# Altium schematic sheet Keyboard_Mouse_LED_Monitor.SchDoc
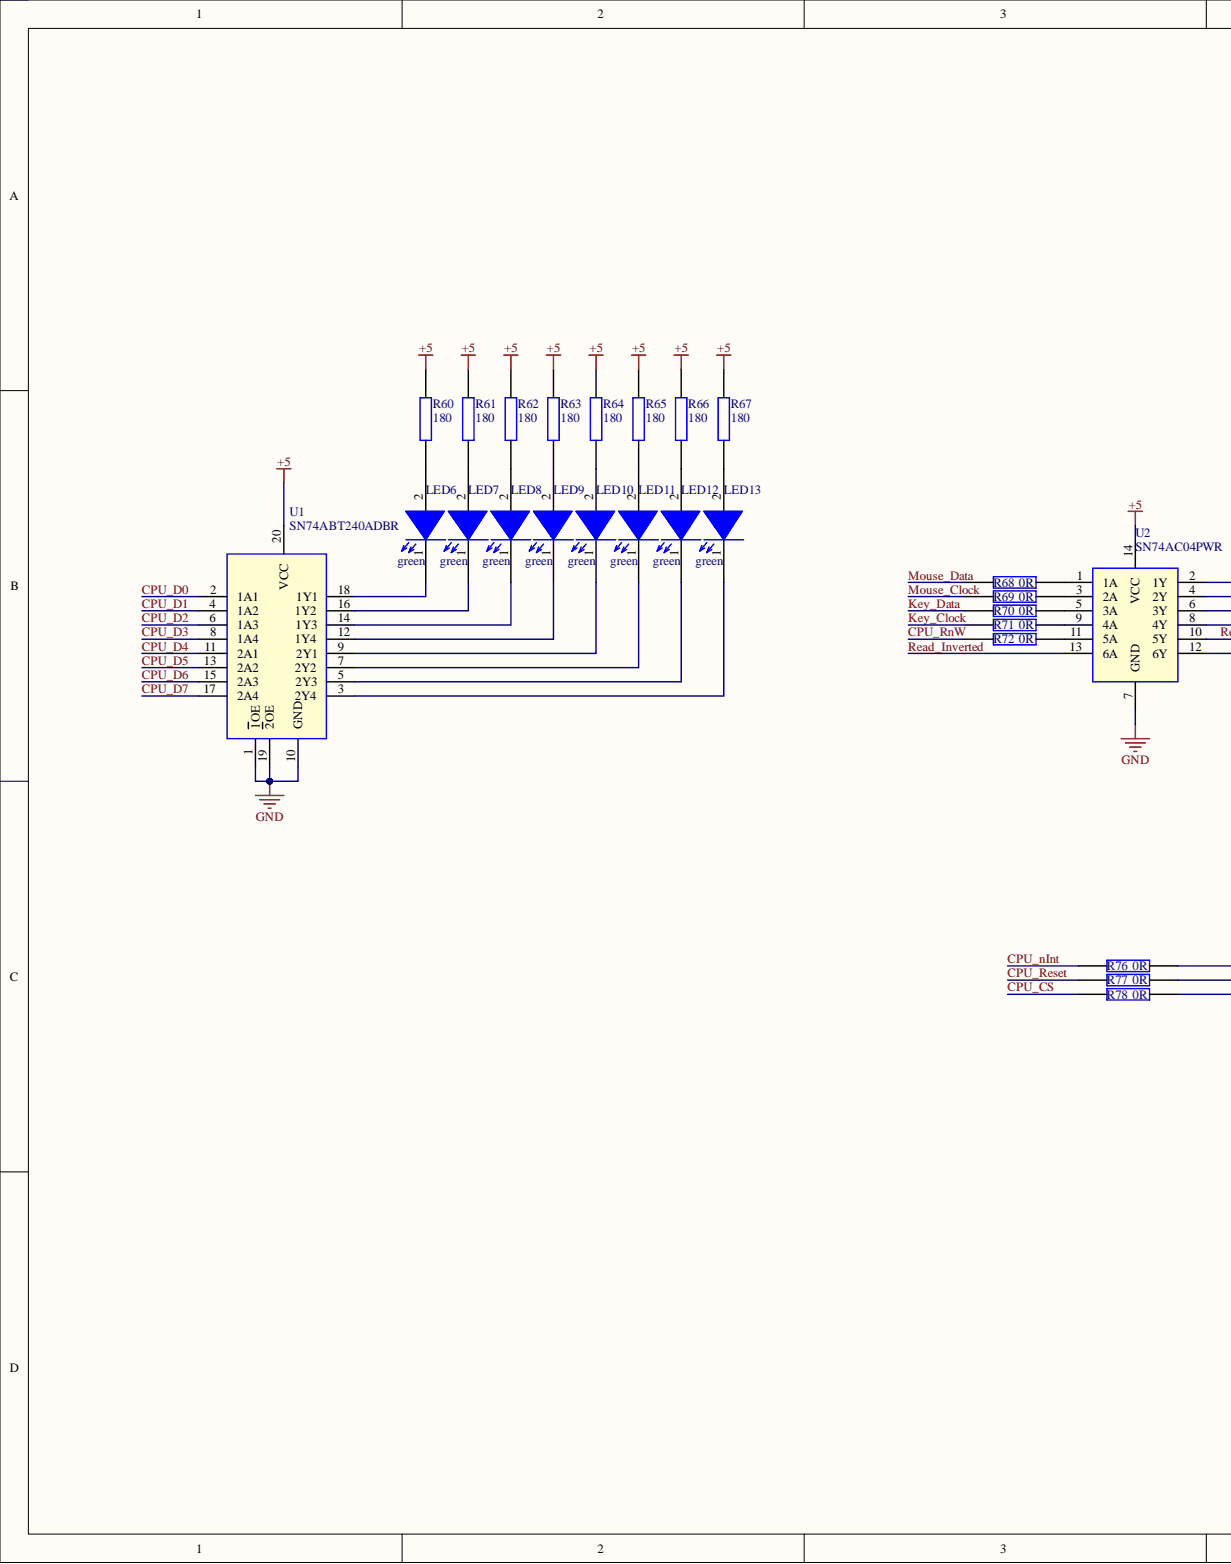
[diagram p0: Keyboard_Mouse_LED_Monitor.SchDoc, left]
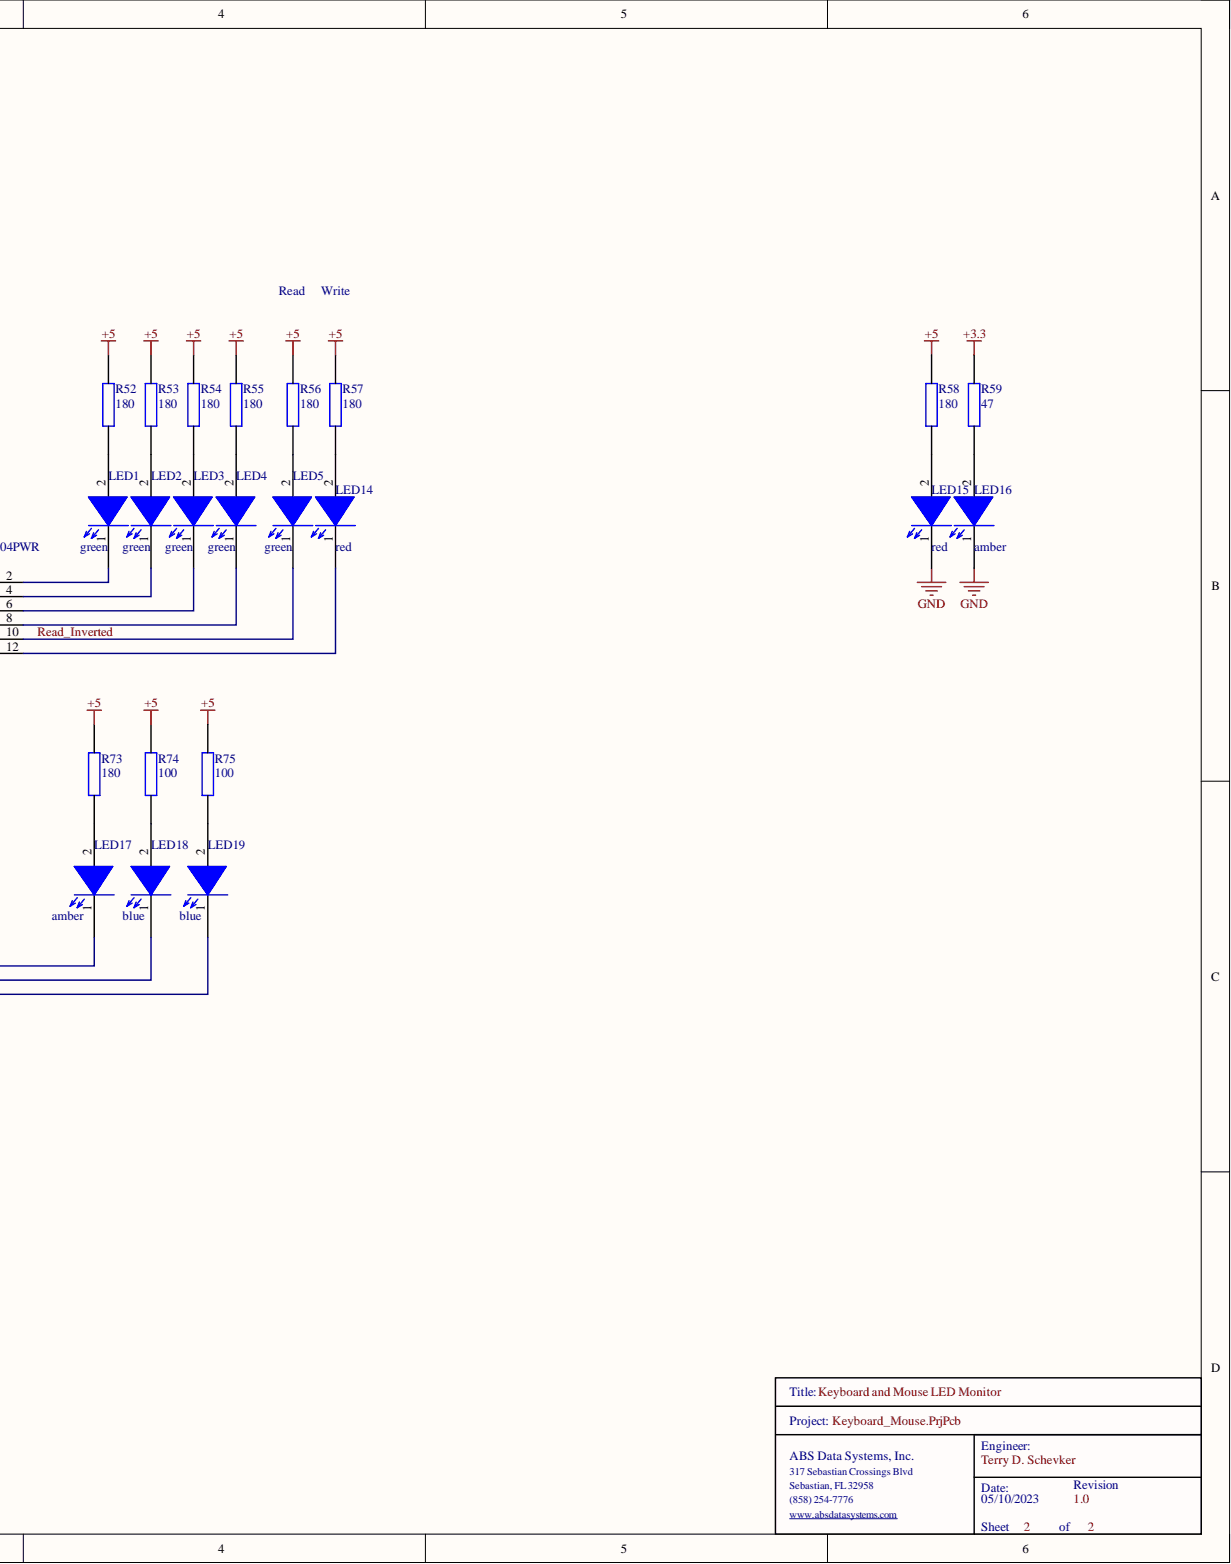
[diagram p1: Keyboard_Mouse_LED_Monitor.SchDoc, right]

## Parts
LED1  green  [0603_Diode]
LED2  green  [0603_Diode]
LED3  green  [0603_Diode]
LED4  green  [0603_Diode]
LED5  green  [0603_Diode]
LED6  green  [0603_Diode]
LED7  green  [0603_Diode]
LED8  green  [0603_Diode]
LED9  green  [0603_Diode]
LED10  green  [0603_Diode]
LED11  green  [0603_Diode]
LED12  green  [0603_Diode]
LED13  green  [0603_Diode]
LED14  red  [0603_Diode]
LED15  red  [0603_Diode]
LED16  amber  [0603_Diode]
LED17  amber  [0603_Diode]
LED18  blue  [0603_Diode]
LED19  blue  [0603_Diode]
R52  180  [0603]
R53  180  [0603]
R54  180  [0603]
R55  180  [0603]
R56  180  [0603]
R57  180  [0603]
R58  180  [0603]
R59  47  [0603]
R60  180  [0603]
R61  180  [0603]
R62  180  [0603]
R63  180  [0603]
R64  180  [0603]
R65  180  [0603]
R66  180  [0603]
R67  180  [0603]
R68  0R  [0603]
R69  0R  [0603]
R70  0R  [0603]
R71  0R  [0603]
R72  0R  [0603]
R73  180  [0603]
R74  100  [0603]
R75  100  [0603]
R76  0R  [0603]
R77  0R  [0603]
R78  0R  [0603]
U1  SN74ABT240ADBR  [SOP65P780X200-20N]
U2  SN74AC04PWR  [SOP65P640X120-14N]

## Nets
+3.3: R59.1
+5: R52.2 R53.2 R54.2 R55.2 R56.2 R57.2 R58.2 R60.2 R61.2 R62.2 R63.2 R64.2 R65.2 R66.2 R67.2 R73.2 R74.1 R75.1 U1.20(VCC) U2.14(VCC)
GND: LED15.1 LED16.1 U1.1(1OE) U1.10(GND) U1.19(2OE) U2.7(GND)
CPU_CS: R78.1
CPU_D0: U1.2(1A1)
CPU_D1: U1.4(1A2)
CPU_D2: U1.6(1A3)
CPU_D3: U1.8(1A4)
CPU_D4: U1.11(2A1)
CPU_D5: U1.13(2A2)
CPU_D6: U1.15(2A3)
CPU_D7: U1.17(2A4)
CPU_nInt: R76.1
CPU_Reset: R77.1
CPU_RnW: R72.1
Key_Clock: R71.1
Key_Data: R70.1
Mouse_Clock: R69.1
Mouse_Data: R68.1
Read_Inverted: LED5.1 U2.10(5Y) U2.13(6A)
NetLED1_1: LED1.1 U2.2(1Y)
NetLED1_2: LED1.2 R52.1
NetLED2_1: LED2.1 U2.4(2Y)
NetLED2_2: LED2.2 R53.1
NetLED3_1: LED3.1 U2.6(3Y)
NetLED3_2: LED3.2 R54.1
NetLED4_1: LED4.1 U2.8(4Y)
NetLED4_2: LED4.2 R55.1
NetLED5_2: LED5.2 R56.1
NetLED6_1: LED6.1 U1.18(1Y1)
NetLED6_2: LED6.2 R60.1
NetLED7_1: LED7.1 U1.16(1Y2)
NetLED7_2: LED7.2 R61.1
NetLED8_1: LED8.1 U1.14(1Y3)
NetLED8_2: LED8.2 R62.1
NetLED9_1: LED9.1 U1.12(1Y4)
NetLED9_2: LED9.2 R63.1
NetLED10_1: LED10.1 U1.9(2Y1)
NetLED10_2: LED10.2 R64.1
NetLED11_1: LED11.1 U1.7(2Y2)
NetLED11_2: LED11.2 R65.1
NetLED12_1: LED12.1 U1.5(2Y3)
NetLED12_2: LED12.2 R66.1
NetLED13_1: LED13.1 U1.3(2Y4)
NetLED13_2: LED13.2 R67.1
NetLED14_1: LED14.1 U2.12(6Y)
NetLED14_2: LED14.2 R57.1
NetLED15_2: LED15.2 R58.1
NetLED16_2: LED16.2 R59.2
NetLED17_1: LED17.1 R76.2
NetLED17_2: LED17.2 R73.1
NetLED18_1: LED18.1 R77.2
NetLED18_2: LED18.2 R74.2
NetLED19_1: LED19.1 R78.2
NetLED19_2: LED19.2 R75.2
NetR68_2: R68.2 U2.1(1A)
NetR69_2: R69.2 U2.3(2A)
NetR70_2: R70.2 U2.5(3A)
NetR71_2: R71.2 U2.9(4A)
NetR72_2: R72.2 U2.11(5A)

## Library data of the parts
LED1-LED13 = 150060GS75000: Wurth Elektronik 0603 green; Manufacturer: Wurth Electronics; Dominant Wavelength: 520nm; Forward Current: 30mA; Forward Voltage: 3.2V; Lens Style: Clear,Rectangular; Luminous Intensity: 430mcd; Test Current: 20mA; Viewing Angle: 140°; Case Code (Imperial): 0603; Case Code (Metric): 1608; Case/Package: 0603; Category: LEDs; Color: Green; Component Kind: Standard; ComponentLink1Description: https://datasheet.ciiva.com/29562/150060gs75000-29562868.pdf?src-supplier=Digi-Key; ComponentLink1URL: https://datasheet.ciiva.com/29562/150060gs75000-29562868.pdf?src-supplier=Digi-Key; ComponentLink2Description: https://datasheet.ciiva.com/29279/759427-da-01-en-chipled-0603-w-kl-gruen-150060gs75000-29279201.pdf?src-supplier=Conrad; ComponentLink2URL: https://datasheet.ciiva.com/29279/759427-da-01-en-chipled-0603-w-kl-gruen-150060gs75000-29279201.pdf?src-supplier=Conrad; ComponentLink3Description: https://datasheet.ciiva.com/29562/150060gs75000-29562869.pdf?src-supplier=Digi-Key; ComponentLink3URL: https://datasheet.ciiva.com/29562/150060gs75000-29562869.pdf?src-supplier=Digi-Key; ComponentLink4Description: https://datasheet.ciiva.com/29562/150060gs75000-29562870.pdf?src-supplier=Digi-Key; ComponentLink4URL: https://datasheet.ciiva.com/29562/150060gs75000-29562870.pdf?src-supplier=Digi-Key; Height: 0.7mm; Lead Free: Lead Free; Length: 1.6mm; Library Name: Misc.SchLib; Manufacturer Part Number: 150060GS75000; Max Operating Temperature: 85°C; Min Operating Temperature: -40°C; Mount: Surface Mount; Package Quantity: 4000; Packaging: Tape and Reel; Pricing 1: 1=0.14000, 50=0.13300, 100=0.11400, 500=0.09900 (USD); REACH SVHC: Unknown; RoHS: Yes; Stock 1: 71509; Supplier 1: Digi-Key; Wavelength: 520nm; Width: 0.8mm
LED14 LED15 = 150060RS75000: Wurth Elektronik 0603 red; Manufacturer: Wurth Electronics; Manufacturer Part Number: 150060GS75000; Color: Green; Dominant Wavelength: 520nm; Forward Current: 30mA; Forward Voltage: 3.2V; Lens Style: Clear,Rectangular; Luminous Intensity: 430mcd; Test Current: 20mA; Viewing Angle: 140°; Case Code (Imperial): 0603; Case Code (Metric): 1608; Case/Package: 0603; Category: LEDs; Component Kind: Standard; ComponentLink1Description: https://datasheet.ciiva.com/29562/150060gs75000-29562868.pdf?src-supplier=Digi-Key; ComponentLink1URL: https://datasheet.ciiva.com/29562/150060gs75000-29562868.pdf?src-supplier=Digi-Key; ComponentLink2Description: https://datasheet.ciiva.com/29279/759427-da-01-en-chipled-0603-w-kl-gruen-150060gs75000-29279201.pdf?src-supplier=Conrad; ComponentLink2URL: https://datasheet.ciiva.com/29279/759427-da-01-en-chipled-0603-w-kl-gruen-150060gs75000-29279201.pdf?src-supplier=Conrad; ComponentLink3Description: https://datasheet.ciiva.com/29562/150060gs75000-29562869.pdf?src-supplier=Digi-Key; ComponentLink3URL: https://datasheet.ciiva.com/29562/150060gs75000-29562869.pdf?src-supplier=Digi-Key; ComponentLink4Description: https://datasheet.ciiva.com/29562/150060gs75000-29562870.pdf?src-supplier=Digi-Key; ComponentLink4URL: https://datasheet.ciiva.com/29562/150060gs75000-29562870.pdf?src-supplier=Digi-Key; Height: 0.7mm; Lead Free: Lead Free; Length: 1.6mm; Library Name: Misc.SchLib; Max Operating Temperature: 85°C; Min Operating Temperature: -40°C; Mount: Surface Mount; Package Quantity: 4000; Packaging: Tape and Reel; Pricing 1: 1=0.14000, 50=0.13300, 100=0.11400, 500=0.09900 (USD); REACH SVHC: Unknown; RoHS: Yes; Stock 1: 71509; Supplier 1: Digi-Key; Wavelength: 520nm; Width: 0.8mm
LED16 LED17 = 150060AS75000: Wurth Elektronik 0603 amber; Manufacturer: Wurth Electronics; Manufacturer Part Number: 150060GS75000; Color: Green; Dominant Wavelength: 520nm; Forward Current: 30mA; Forward Voltage: 3.2V; Lens Style: Clear,Rectangular; Luminous Intensity: 430mcd; Test Current: 20mA; Viewing Angle: 140°; Case Code (Imperial): 0603; Case Code (Metric): 1608; Case/Package: 0603; Category: LEDs; Component Kind: Standard; ComponentLink1Description: https://datasheet.ciiva.com/29562/150060gs75000-29562868.pdf?src-supplier=Digi-Key; ComponentLink1URL: https://datasheet.ciiva.com/29562/150060gs75000-29562868.pdf?src-supplier=Digi-Key; ComponentLink2Description: https://datasheet.ciiva.com/29279/759427-da-01-en-chipled-0603-w-kl-gruen-150060gs75000-29279201.pdf?src-supplier=Conrad; ComponentLink2URL: https://datasheet.ciiva.com/29279/759427-da-01-en-chipled-0603-w-kl-gruen-150060gs75000-29279201.pdf?src-supplier=Conrad; ComponentLink3Description: https://datasheet.ciiva.com/29562/150060gs75000-29562869.pdf?src-supplier=Digi-Key; ComponentLink3URL: https://datasheet.ciiva.com/29562/150060gs75000-29562869.pdf?src-supplier=Digi-Key; ComponentLink4Description: https://datasheet.ciiva.com/29562/150060gs75000-29562870.pdf?src-supplier=Digi-Key; ComponentLink4URL: https://datasheet.ciiva.com/29562/150060gs75000-29562870.pdf?src-supplier=Digi-Key; Height: 0.7mm; Lead Free: Lead Free; Length: 1.6mm; Library Name: Misc.SchLib; Max Operating Temperature: 85°C; Min Operating Temperature: -40°C; Mount: Surface Mount; Package Quantity: 4000; Packaging: Tape and Reel; Pricing 1: 1=0.14000, 50=0.13300, 100=0.11400, 500=0.09900 (USD); REACH SVHC: Unknown; RoHS: Yes; Stock 1: 71509; Supplier 1: Digi-Key; Wavelength: 520nm; Width: 0.8mm
LED18 LED19 = 150060BS75000: Wurth Elektronik 0603 blue; Manufacturer: Wurth Electronics; Manufacturer Part Number: 150060GS75000; Color: Green; Dominant Wavelength: 520nm; Forward Current: 30mA; Forward Voltage: 3.2V; Lens Style: Clear,Rectangular; Luminous Intensity: 430mcd; Test Current: 20mA; Viewing Angle: 140°; Case Code (Imperial): 0603; Case Code (Metric): 1608; Case/Package: 0603; Category: LEDs; Component Kind: Standard; ComponentLink1Description: https://datasheet.ciiva.com/29562/150060gs75000-29562868.pdf?src-supplier=Digi-Key; ComponentLink1URL: https://datasheet.ciiva.com/29562/150060gs75000-29562868.pdf?src-supplier=Digi-Key; ComponentLink2Description: https://datasheet.ciiva.com/29279/759427-da-01-en-chipled-0603-w-kl-gruen-150060gs75000-29279201.pdf?src-supplier=Conrad; ComponentLink2URL: https://datasheet.ciiva.com/29279/759427-da-01-en-chipled-0603-w-kl-gruen-150060gs75000-29279201.pdf?src-supplier=Conrad; ComponentLink3Description: https://datasheet.ciiva.com/29562/150060gs75000-29562869.pdf?src-supplier=Digi-Key; ComponentLink3URL: https://datasheet.ciiva.com/29562/150060gs75000-29562869.pdf?src-supplier=Digi-Key; ComponentLink4Description: https://datasheet.ciiva.com/29562/150060gs75000-29562870.pdf?src-supplier=Digi-Key; ComponentLink4URL: https://datasheet.ciiva.com/29562/150060gs75000-29562870.pdf?src-supplier=Digi-Key; Height: 0.7mm; Lead Free: Lead Free; Length: 1.6mm; Library Name: Misc.SchLib; Max Operating Temperature: 85°C; Min Operating Temperature: -40°C; Mount: Surface Mount; Package Quantity: 4000; Packaging: Tape and Reel; Pricing 1: 1=0.14000, 50=0.13300, 100=0.11400, 500=0.09900 (USD); REACH SVHC: Unknown; RoHS: Yes; Stock 1: 71509; Supplier 1: Digi-Key; Wavelength: 520nm; Width: 0.8mm
R52-R58 R60-R67 R73 = RC0603FR-13180RL: YAGEO 0603 180 ohm; Manufacturer_Name: KEMET; Manufacturer_Part_Number: RC0603JR-070RL; Geometry.Height: 0.55mm; Component Kind: Standard; Datasheet Link: https://datasheet.datasheetarchive.com/originals/distributors/Datasheets_SAMA/902f9e387b938f871d31120f5fc1d65e.pdf; Library Name: Resistors.SchLib; Mouser Part Number: 603-RC0603JR-070RL; Mouser Price/Stock: https://www.mouser.co.uk/ProductDetail/YAGEO/RC0603JR-070RL?qs=2cAdsCoAWRGWyPcuXgGiXQ%3D%3D
R59 = RC0603FR-0747KL: YAGEO 0603 47; Manufacturer_Name: KEMET; Manufacturer_Part_Number: RC0603JR-070RL; Geometry.Height: 0.55mm; Component Kind: Standard; Datasheet Link: https://datasheet.datasheetarchive.com/originals/distributors/Datasheets_SAMA/902f9e387b938f871d31120f5fc1d65e.pdf; Library Name: Resistors.SchLib; Mouser Part Number: 603-RC0603JR-070RL; Mouser Price/Stock: https://www.mouser.co.uk/ProductDetail/YAGEO/RC0603JR-070RL?qs=2cAdsCoAWRGWyPcuXgGiXQ%3D%3D
R68-R72 R76-R78 = RC0603JR-070RL: YAGEO 0603 0 ohm; Manufacturer_Name: KEMET; Geometry.Height: 0.55mm; Component Kind: Standard; Datasheet Link: https://datasheet.datasheetarchive.com/originals/distributors/Datasheets_SAMA/902f9e387b938f871d31120f5fc1d65e.pdf; Library Name: Resistors.SchLib; Manufacturer_Part_Number: RC0603JR-070RL; Mouser Part Number: 603-RC0603JR-070RL; Mouser Price/Stock: https://www.mouser.co.uk/ProductDetail/YAGEO/RC0603JR-070RL?qs=2cAdsCoAWRGWyPcuXgGiXQ%3D%3D
R74 R75 = RC0603FR-10100RL: YAGEO 0603 100 ohm; Manufacturer_Name: KEMET; Manufacturer_Part_Number: RC0603JR-070RL; Geometry.Height: 0.55mm; Component Kind: Standard; Datasheet Link: https://datasheet.datasheetarchive.com/originals/distributors/Datasheets_SAMA/902f9e387b938f871d31120f5fc1d65e.pdf; Library Name: Resistors.SchLib; Mouser Part Number: 603-RC0603JR-070RL; Mouser Price/Stock: https://www.mouser.co.uk/ProductDetail/YAGEO/RC0603JR-070RL?qs=2cAdsCoAWRGWyPcuXgGiXQ%3D%3D
U1 = SN74ABT240ADBR: Octal Buffers/Drivers With 3-State Outputs; Manufacturer_Name: Texas Instruments; Geometry.Height: 2mm; Component Kind: Standard; Datasheet Link: http://www.ti.com/lit/gpn/sn74abt240a; Library Name: Logic.SchLib; Manufacturer_Part_Number: SN74ABT240ADBR; Mouser Part Number: 595-SN74ABT240ADBR; Mouser Price/Stock: https://www.mouser.co.uk/ProductDetail/Texas-Instruments/SN74ABT240ADBR?qs=JHHQeKcAU3Dt82k%252BVyiYdw%3D%3D
U2 = SN74AC04PWR: Hex Inverters; Manufacturer_Name: Texas Instruments; Geometry.Height: 1.2mm; Component Kind: Standard; Datasheet Link: http://www.ti.com/lit/gpn/sn74ac04; Library Name: Logic.SchLib; Manufacturer_Part_Number: SN74AC04PWR; Mouser Part Number: 595-SN74AC04PWR; Mouser Price/Stock: https://www.mouser.co.uk/ProductDetail/Texas-Instruments/SN74AC04PWR?qs=RZS%252BpEsUn7pRvPNqsga4SA%3D%3D
